# Altium schematic sheet Sheet1.SchDoc(Sheet1)
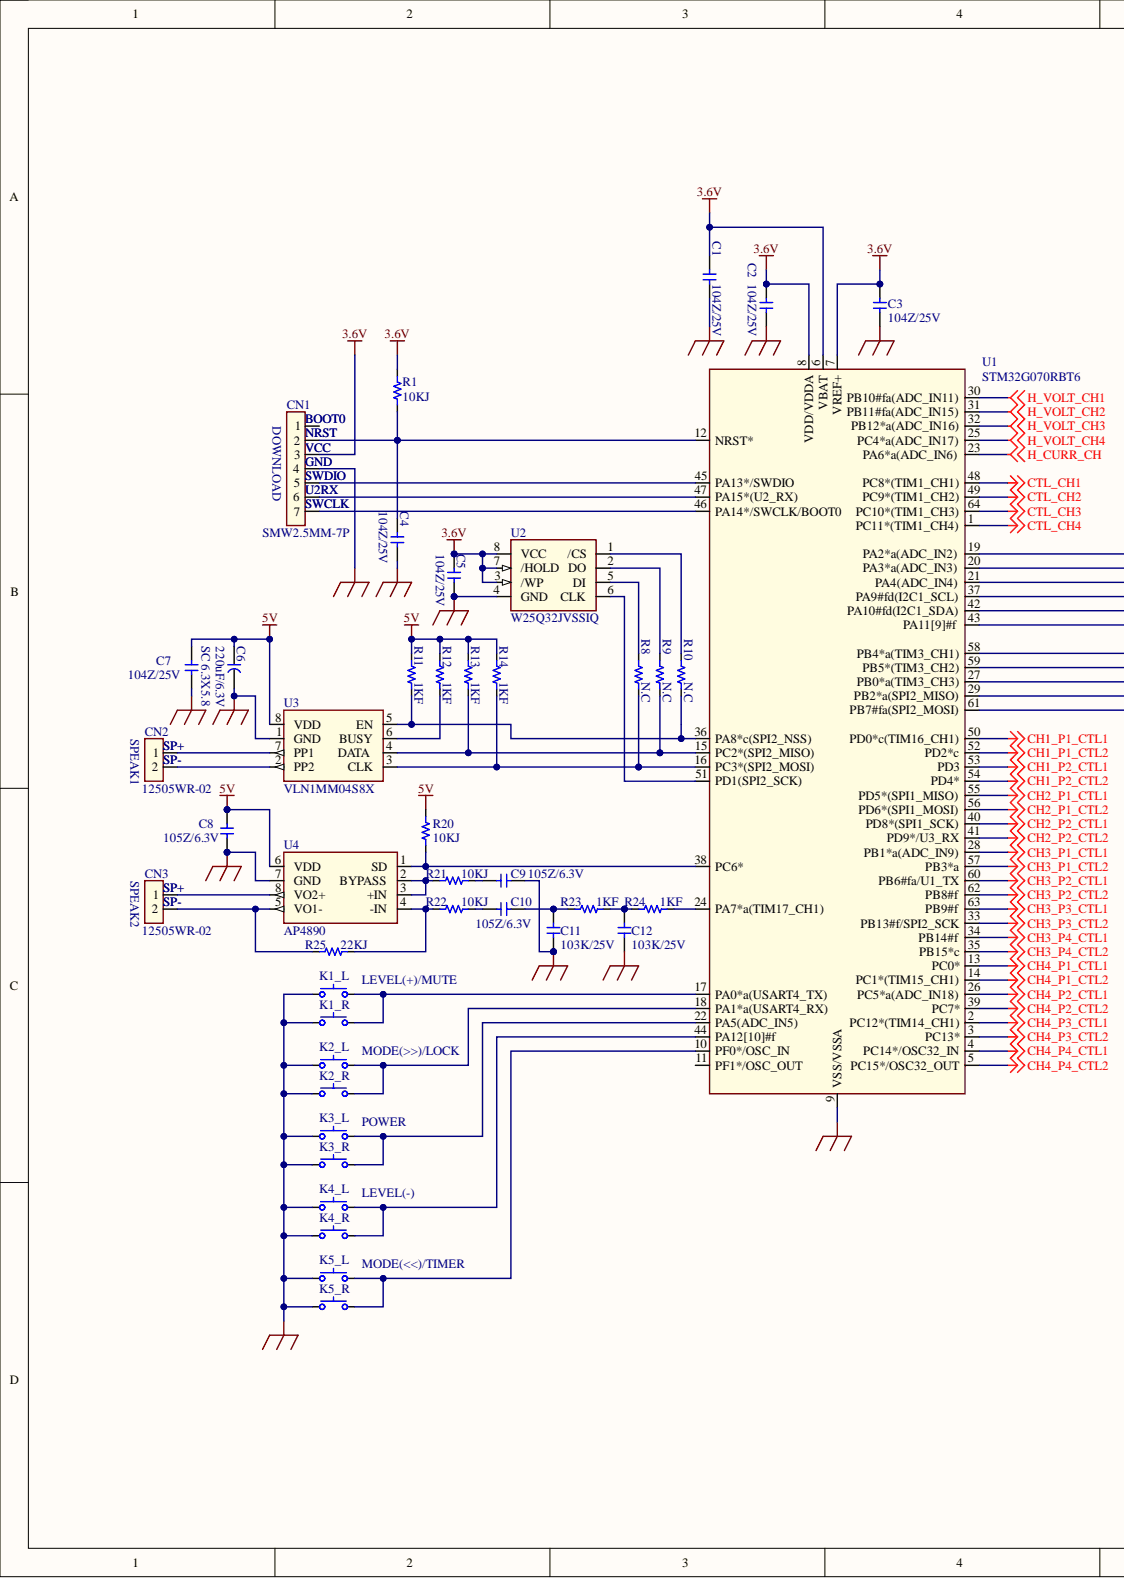
[diagram p0: Sheet1.SchDoc, left]
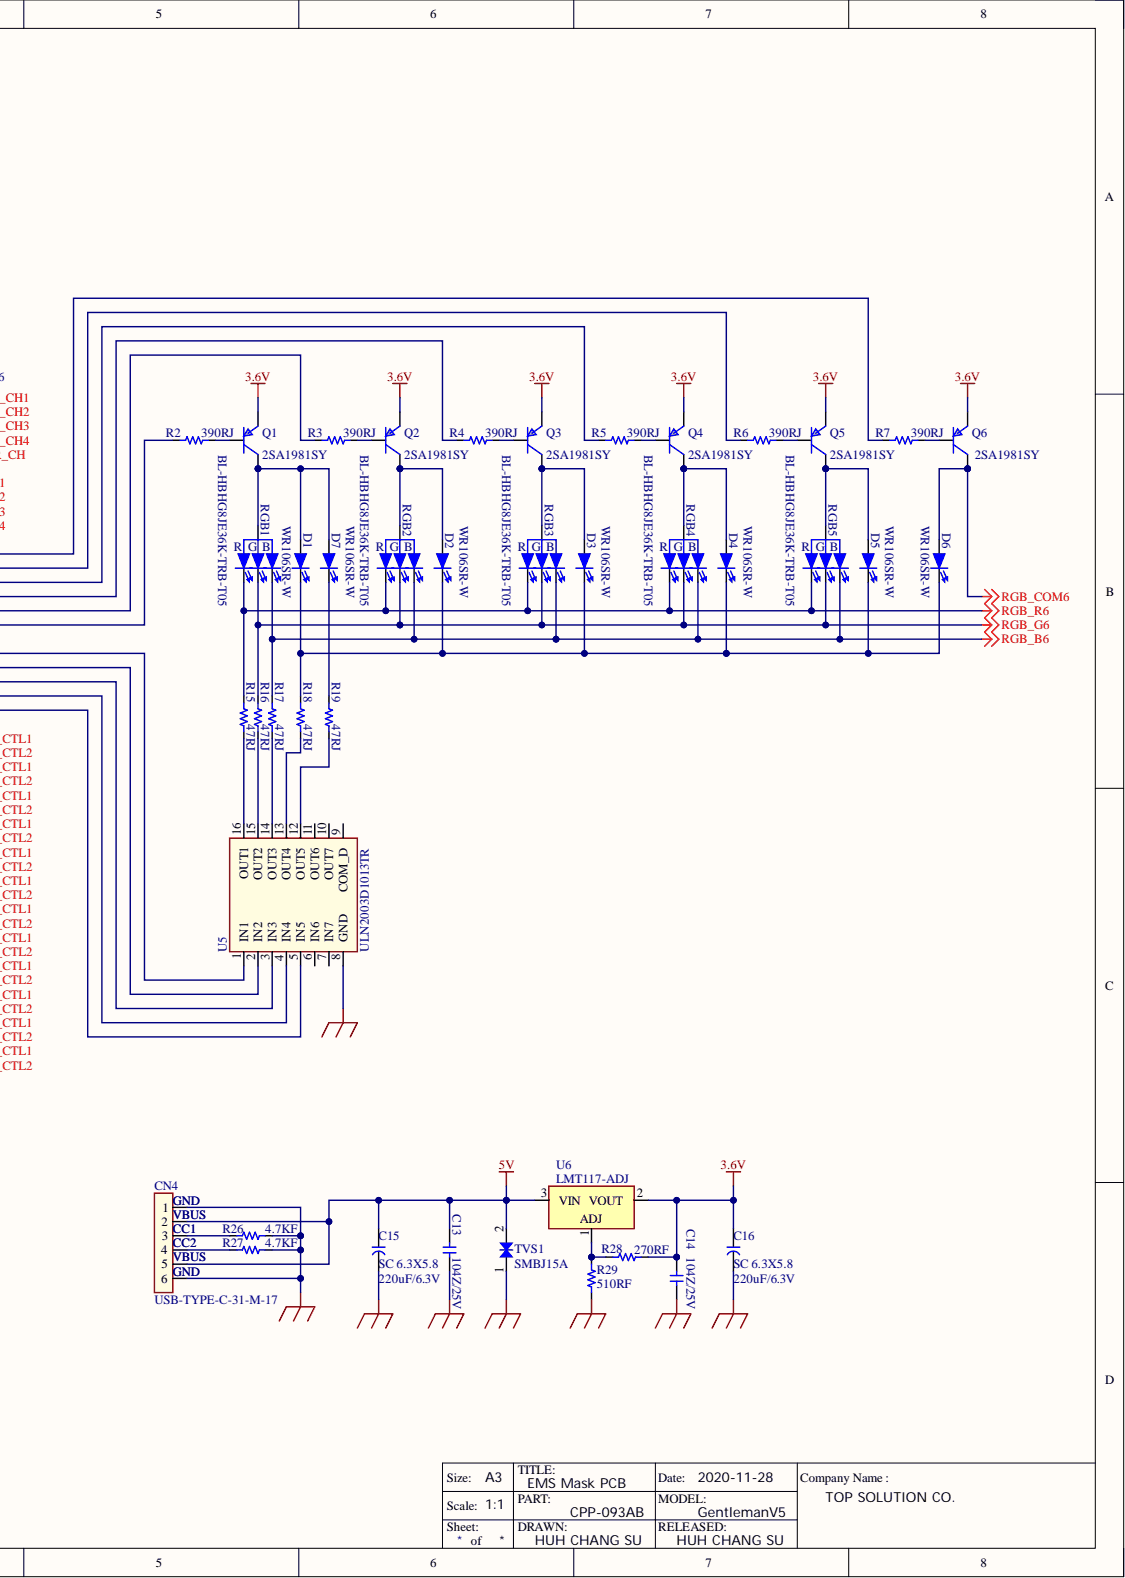
[diagram p1: Sheet1.SchDoc, right]

## Parts
C1  104Z/25V  [SMD C 0603]
C2  104Z/25V  [SMD C 0603]
C3  104Z/25V  [SMD C 0603]
C4  104Z/25V  [SMD C 0603]
C5  104Z/25V  [SMD C 0603]
C6  220uF/6.3V  [SMD SC D(6.3*5.4)]
C7  104Z/25V  [SMD C 0603]
C8  105Z/6.3V  [SMD C 0603]
C9  105Z/6.3V  [SMD C 0603]
C10  105Z/6.3V  [SMD C 0603]
C11  103K/25V  [SMD C 0603]
C12  103K/25V  [SMD C 0603]
C13  104Z/25V  [SMD C 0603]
C14  104Z/25V  [SMD C 0603]
C15  220uF/6.3V  [SMD SC D(6.3*5.4)]
C16  220uF/6.3V  TYPE: SC 6.3X5.8  [SMD SC D(6.3*5.4)]
CN1  SMW2.5MM-7P  EXPLANATION: DOWNLOAD  [MI HDR-7X1]
CN2  12505WR-02  EXPLANATION: SPEAK1  [SMD 12505WR-02]
CN3  12505WR-02  EXPLANATION: SPEAK2  [SMD 12505WR-02]
CN4  USB-TYPE-C-31-M-17  [USB-C-TYPE-6PIN]
D1  WR106SR-W  [SMD LED 0603R]
D2  WR106SR-W  [SMD LED 0603R]
D3  WR106SR-W  [SMD LED 0603R]
D4  WR106SR-W  [SMD LED 0603R]
D5  WR106SR-W  [SMD LED 0603R]
D6  WR106SR-W  [SMD LED 0603R]
D7  WR106SR-W  [SMD LED 0603R]
K1_L  EXPLANATION: LEVEL(+)/MUTE  [SMD CARBON-4PI]  (hidden comment: CORBON CONTACT(4PI))
K1_R  CORBON CONTACT(4PI)  [SMD CARBON-4PI]  (value not drawn)
K2_L  EXPLANATION: MODE(>>)/LOCK  [SMD CARBON-4PI]  (hidden comment: CORBON CONTACT(4PI))
K2_R  CORBON CONTACT(4PI)  [SMD CARBON-4PI]  (value not drawn)
K3_L  EXPLANATION: POWER  [SMD CARBON-4PI]  (hidden comment: CORBON CONTACT(4PI))
K3_R  CORBON CONTACT(4PI)  [SMD CARBON-4PI]  (value not drawn)
K4_L  EXPLANATION: LEVEL(-)  [SMD CARBON-4PI]  (hidden comment: CORBON CONTACT(4PI))
K4_R  CORBON CONTACT(4PI)  [SMD CARBON-4PI]  (value not drawn)
K5_L  EXPLANATION: MODE(<<)/TIMER  [SMD CARBON-4PI]  (hidden comment: CORBON CONTACT(4PI))
K5_R  CORBON CONTACT(4PI)  [SMD CARBON-4PI]  (value not drawn)
Q1  2SA1981SY  [SOT23-3N]
Q2  2SA1981SY  [SOT23-3N]
Q3  2SA1981SY  [SOT23-3N]
Q4  2SA1981SY  [SOT23-3N]
Q5  2SA1981SY  [SOT23-3N]
Q6  2SA1981SY  [SOT23-3N]
R1  10KJ  [SR 0603]
R2  390RJ  [SR 0603]
R3  390RJ  [SR 0603]
R4  390RJ  [SR 0603]
R5  390RJ  [SR 0603]
R6  390RJ  [SR 0603]
R7  390RJ  [SR 0603]
R8  N.C  [SR 0603]
R9  N.C  [SR 0603]
R10  N.C  [SR 0603]
R11  1KF  [SR 0603]
R12  1KF  [SR 0603]
R13  1KF  [SR 0603]
R14  1KF  [SR 0603]
R15  47RJ  [SR 0603]
R16  47RJ  [SR 0603]
R17  47RJ  [SR 0603]
R18  47RJ  [SR 0603]
R19  47RJ  [SR 0603]
R20  10KJ  [SR 0603]
R21  10KJ  [SR 0603]
R22  10KJ  [SR 0603]
R23  1KF  [SR 0603]
R24  1KF  [SR 0603]
R25  22KJ  [SR 0603]
R26  4.7KF  [SR 0603]
R27  4.7KF  [SR 0603]
R28  270RF  [SR 0603]
R29  510RF  [SR 0603]
RGB1  BL-HBHG8JE36K-TRB-T05  [SMD LED 0605W]
RGB2  BL-HBHG8JE36K-TRB-T05  [SMD LED 0605W]
RGB3  BL-HBHG8JE36K-TRB-T05  [SMD LED 0605W]
RGB4  BL-HBHG8JE36K-TRB-T05  [SMD LED 0605W]
RGB5  BL-HBHG8JE36K-TRB-T05  [SMD LED 0605W]
TVS1  SMBJ15A  [SD SMB]
U1  STM32G070RBT6  [SMD LQFP64 10x10_N]
U2  W25Q32JVSSIQ  [SOP8W_L]
U3  VLN1MM04S8X  [SOP8_M]
U4  AP4890  [SOP8_MP2.8X2]
U5  ULN2003D1013TR  [SOP16_M]
U6  LMT117-ADJ  [SOT223_N]

## Nets
3.6V: C1.2 C2.2 C3.2 C5.2 C14.2 C16.1 CN1.3 Q1.2 Q2.2 Q3.2 Q4.2 Q5.2 Q6.2 R1.2 R28.1 U1.6(VBAT) U1.7(VREF+) U1.8(VDD/VDDA) U2.3(/WP) U2.7(/HOLD) U2.8(VCC) U6.2
5V: C6.1 C7.2 C8.2 C13.2 C15.1 CN4.2 CN4.5 R11.2 R12.2 R13.2 R14.2 R20.2 TVS1.2 U3.8(VDD) U4.6(VDD) U6.3
CH1_P1_CTL1: U1.50(PD0*c(TIM16_CH1))
CH1_P1_CTL2: U1.52(PD2*c)
CH1_P2_CTL1: U1.53(PD3)
CH1_P2_CTL2: U1.54(PD4*)
CH2_P1_CTL1: U1.55(PD5*(SPI1_MISO))
CH2_P1_CTL2: U1.56(PD6*(SPI1_MOSI))
CH2_P2_CTL1: U1.40(PD8*(SPI1_SCK))
CH2_P2_CTL2: U1.41(PD9*/U3_RX)
CH3_P1_CTL1: U1.28(PB1*a(ADC_IN9))
CH3_P1_CTL2: U1.57(PB3*a)
CH3_P2_CTL1: U1.60(PB6#fa/U1_TX)
CH3_P2_CTL2: U1.62(PB8#f)
CH3_P3_CTL1: U1.63(PB9#f)
CH3_P3_CTL2: U1.33(PB13#f/SPI2_SCK)
CH3_P4_CTL1: U1.34(PB14#f)
CH3_P4_CTL2: U1.35(PB15*c)
CH4_P1_CTL1: U1.13(PC0*)
CH4_P1_CTL2: U1.14(PC1*(TIM15_CH1))
CH4_P2_CTL1: U1.26(PC5*a(ADC_IN18))
CH4_P2_CTL2: U1.39(PC7*)
CH4_P3_CTL1: U1.2(PC12*(TIM14_CH1))
CH4_P3_CTL2: U1.3(PC13*)
CH4_P4_CTL1: U1.4(PC14*/OSC32_IN)
CH4_P4_CTL2: U1.5(PC15*/OSC32_OUT)
CTL_CH1: U1.48(PC8*(TIM1_CH1))
CTL_CH2: U1.49(PC9*(TIM1_CH2))
CTL_CH3: U1.64(PC10*(TIM1_CH3))
CTL_CH4: U1.1(PC11*(TIM1_CH4))
GND: C1.1 C2.1 C3.1 C4.1 C5.1 C6.2 C7.1 C8.1 C9.1 C11.1 C12.1 C13.1 C14.1 C15.2 C16.2 CN1.4 CN4.1 CN4.6 K1_L.1 K1_R.2 K2_L.1 K2_R.2 K3_L.1 K3_R.2 K4_L.1 K4_R.2 K5_L.1 K5_R.2 R26.2 R27.2 R29.2 TVS1.1 U1.9(VSS/VSSA) U2.4(GND) U3.1(GND) U4.7(GND) U5.8(GND)
H_CURR_CH: U1.23(PA6*a(ADC_IN6))
H_VOLT_CH1: U1.30(PB10#fa(ADC_IN11))
H_VOLT_CH2: U1.31(PB11#fa(ADC_IN15))
H_VOLT_CH3: U1.32(PB12*a(ADC_IN16))
H_VOLT_CH4: U1.25(PC4*a(ADC_IN17))
RGB_B6: R17.2 RGB1.4 RGB2.4 RGB3.4 RGB4.4 RGB5.4
RGB_COM6: D6.1 Q6.3
RGB_G6: R16.2 RGB1.3 RGB2.3 RGB3.3 RGB4.3 RGB5.3
RGB_R6: R15.2 RGB1.2 RGB2.2 RGB3.2 RGB4.2 RGB5.2
NetC4_2: C4.2 CN1.2 R1.1 U1.12(NRST*)
NetC9_2: C9.2 R21.1
NetC10_1: C10.1 C11.2 R23.1
NetC10_2: C10.2 R22.2
NetC12_2: C12.2 R23.2 R24.1
NetCN1_5: CN1.5 U1.45(PA13*/SWDIO)
NetCN1_6: CN1.6 U1.47(PA15*(U2_RX))
NetCN1_7: CN1.7 U1.46(PA14*/SWCLK/BOOT0)
NetCN2_1: CN2.1 U3.7(PP1)
NetCN2_2: CN2.2 U3.2(PP2)
NetCN3_1: CN3.1 U4.8(VO2+)
NetCN3_2: CN3.2 R25.1 U4.5(VO1-)
NetCN4_3: CN4.3 R26.1
NetCN4_4: CN4.4 R27.1
NetD1_1: D1.1 D7.1 Q1.3 RGB1.1(GB)
NetD1_2: D1.2 D2.2 D3.2 D4.2 D5.2 D6.2 R18.2
NetD2_1: D2.1 Q2.3 RGB2.1(GB)
NetD3_1: D3.1 Q3.3 RGB3.1(GB)
NetD4_1: D4.1 Q4.3 RGB4.1(GB)
NetD5_1: D5.1 Q5.3 RGB5.1(GB)
NetD7_2: D7.2 R19.2
NetK1_L_2: K1_L.2 K1_R.1 U1.17(PA0*a(USART4_TX))
NetK2_L_2: K2_L.2 K2_R.1 U1.18(PA1*a(USART4_RX))
NetK3_L_2: K3_L.2 K3_R.1 U1.22(PA5(ADC_IN5))
NetK4_L_2: K4_L.2 K4_R.1 U1.44(PA12[10]#f)
NetK5_L_2: K5_L.2 K5_R.1 U1.10(PF0*/OSC_IN)
NetQ1_1: Q1.1 R2.2
NetQ2_1: Q2.1 R3.2
NetQ3_1: Q3.1 R4.2
NetQ4_1: Q4.1 R5.2
NetQ5_1: Q5.1 R6.2
NetQ6_1: Q6.1 R7.2
NetR2_1: R2.1 U1.43(PA11[9]#f)
NetR3_1: R3.1 U1.42(PA10#fd(I2C1_SDA))
NetR4_1: R4.1 U1.37(PA9#fd(I2C1_SCL))
NetR5_1: R5.1 U1.21(PA4(ADC_IN4))
NetR6_1: R6.1 U1.20(PA3*a(ADC_IN3))
NetR7_1: R7.1 U1.19(PA2*a(ADC_IN2))
NetR8_1: R8.1 R14.1 U1.16(PC3*(SPI2_MOSI)) U3.3(CLK)
NetR8_2: R8.2 U2.5(DI)
NetR9_1: R9.1 R13.1 U1.15(PC2*(SPI2_MISO)) U3.4(DATA)
NetR9_2: R9.2 U2.2(DO)
NetR10_1: R10.1 R11.1 U1.36(PA8*c(SPI2_NSS)) U3.5(EN)
NetR10_2: R10.2 U2.1(/CS)
NetR12_1: R12.1 U3.6(BUSY)
NetR15_1: R15.1 U5.16(OUT1)
NetR16_1: R16.1 U5.15(OUT2)
NetR17_1: R17.1 U5.14(OUT3)
NetR18_1: R18.1 U5.13(OUT4)
NetR19_1: R19.1 U5.12(OUT5)
NetR20_1: R20.1 U1.38(PC6*) U4.1(SD)
NetR21_2: R21.2 U4.2(BYPASS) U4.3(+IN)
NetR22_1: R22.1 R25.2 U4.4(-IN)
NetR24_2: R24.2 U1.24(PA7*a(TIM17_CH1))
NetR28_2: R28.2 R29.1 U6.1
NetU1_27: U1.27(PB0*a(TIM3_CH3)) U5.3(IN3)
NetU1_29: U1.29(PB2*a(SPI2_MISO)) U5.4(IN4)
NetU1_51: U1.51(PD1(SPI2_SCK)) U2.6(CLK)
NetU1_58: U1.58(PB4*a(TIM3_CH1)) U5.1(IN1)
NetU1_59: U1.59(PB5*(TIM3_CH2)) U5.2(IN2)
NetU1_61: U1.61(PB7#fa(SPI2_MOSI)) U5.5(IN5)

Not connected: CN1 1; U1 11(PF1*/OSC_OUT); U5 6(IN6) 7(IN7) 9(COM_D) 10(OUT7) 11(OUT6)

## Library data of the parts
C1-C5 C7-C14 = C: CHIP CERAMIC CAP; MAKER: WALSIN, SAMSUNG; TYPE: 1608; Component Kind: Standard; Library Name: Integrated_Library.IntLib
  C1-C5 C7 C11-C14: COST: 5.4
  C8-C10: COST: 9.6
C6 C15 = C-CM: SMD ELECT CAPACITOR; MAKER: SAMYOUNG; TYPE: SC 6.3X5.8; Component Kind: Standard; COST: 42; Library Name: Integrated_Library.IntLib
C16 = C-CM: SMD ELECT CAPACITOR; MAKER: SAMYOUNG; Component Kind: Standard; COST: 42; Library Name: Integrated_Library.IntLib
CN1 = CON 7P: PIN HEADER; MAKER: YEONHO ELECTRONICS; Component Kind: Standard; COST: 80; Library Name: Integrated_Library.IntLib; TYPE: DIP-8
CN2 CN3 = CON 2P: SMD WAFER; MAKER: YEONHO ELECTRONICS; Component Kind: Standard; COST: 60; Library Name: Integrated_Library.IntLib; TYPE: SMD-2
CN4 = USB-C-3.1-6P: SMD JACK USB C TYPE; MAKER: Korean-Hroparts-Elec; Component Kind: Standard; COST: 200; Library Name: Integrated_Library.IntLib; TYPE: SMD-6
D1-D7 = LED: CHIP LED RED; MAKER: BRIGHT LED; TYPE: 1608; Component Kind: Standard; COST: 31; EXPLANATION: RED; Library Name: Integrated_Library.IntLib
K1_L K2_L K3_L K4_L K5_L = SW_CARBON_A: PATTERN CARBON SWITCH; Component Kind: Standard; COST: 0; Library Name: Integrated_Library.IntLib; TYPE: CARBON-4PI
K1_R K2_R K3_R K4_R K5_R = SW_CARBON_B: PATTERN CARBON SWITCH; Component Kind: Standard; COST: 0; Library Name: Integrated_Library.IntLib; TYPE: CARBON-4PI
Q1-Q6 = 2SA1981SY: CHIP TRANSISTOR; MAKER: AUK; TYPE: SOT-23; Component Kind: Standard; COST: 24; Library Name: Integrated_Library.IntLib
R1-R29 = R: CHIP RESISTOR; MAKER: WALSIN, SAMSUNG; Component Kind: Standard; COST: 1.2; Library Name: Integrated_Library.IntLib; TYPE: 1608
RGB1-RGB5 = LED_RGB: CHIP LED RGB; EXPLANATION: RGB COLOR; MAKER: BRIGHT LED; Component Kind: Standard; COST: 100; Library Name: Integrated_Library.IntLib; TYPE: 1615
TVS1 = TVS: SMD DIODE TVS; MAKER: 'SAMYANG ELECTRONICS; 구입처: KEC; Component Kind: Standard; COST: 100; Library Name: Integrated_Library.IntLib; TYPE: SMB
U1 = STM32G070RBT6: SMD MCU; MAKER: STMicroelectronics; Component Kind: Standard; COST: 1350; Library Name: Integrated_Library.IntLib; TYPE: LQFP64
U2 = W25Q32JVSSIQ: SMD SERIAL FLASH; MAKER: WINBOND; Component Kind: Standard; COST: 390; Library Name: Integrated_Library.IntLib; TYPE: SOIC-8(208mil)
U3 = VLN1MM04S8X: SMD VOICE; MAKER: SYNOOS; Component Kind: Standard; COST: 672; Library Name: Integrated_Library.IntLib; TYPE: SOP-8
U4 = AP4890B-SOP8: SMD AMPLIFIER 1W; MAKER: APLUS; Component Kind: Standard; COST: 470; Library Name: Integrated_Library.IntLib; TYPE: SOP8
U5 = ULN2003D1013TR: SMD BUFFER; MAKER: STMicroelectronics; Component Kind: Standard; COST: 178; Library Name: Integrated_Library.IntLib; TYPE: SOP-16
U6 = LMT117-ADJ: SMD REGULATOR; MAKER: TGS; Component Kind: Standard; COST: 105; Library Name: Integrated_Library.IntLib; TYPE: SOT-223
